# Altium schematic sheet 1_FOCUS.SchDoc(1_FOCUS)
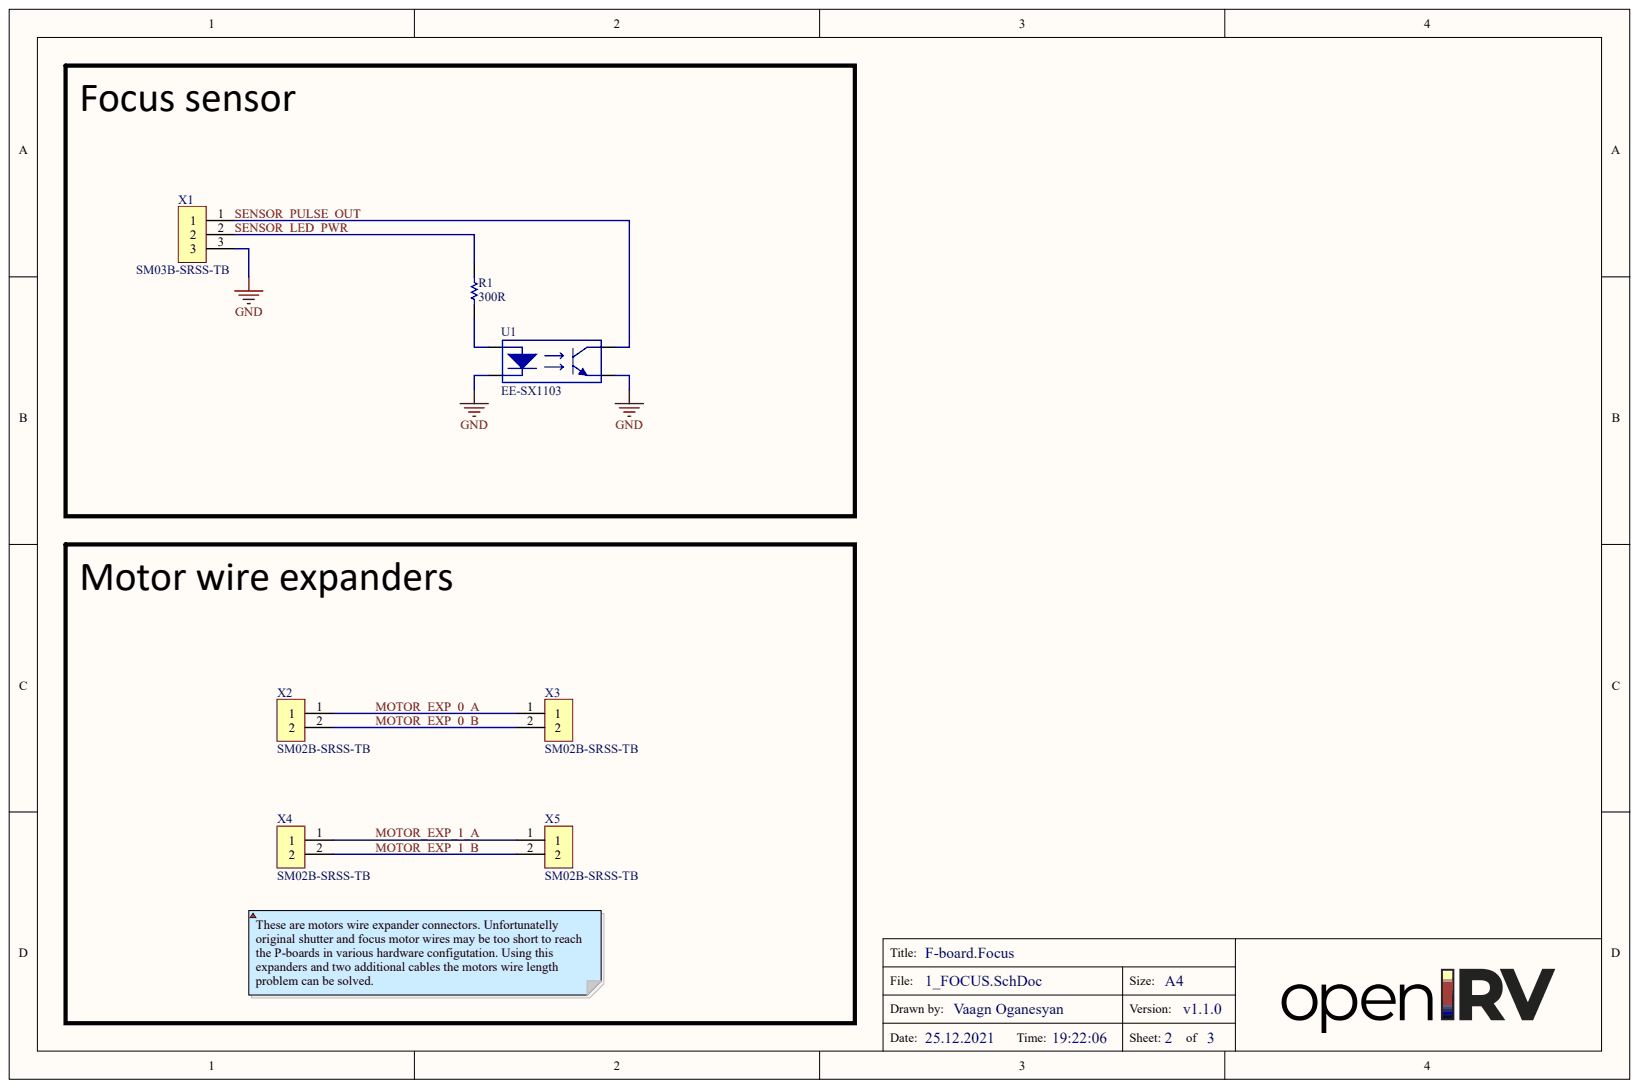
[diagram p0: 1_FOCUS.SchDoc]

## Parts
R1  300R  [R0603[1608]]
U1  EE-SX1103  [EE-SX1103]
X1  SM03B-SRSS-TB  [SM03B-SRSS-TB]
X2  SM02B-SRSS-TB  [SM02B-SRSS-TB]
X3  SM02B-SRSS-TB  [SM02B-SRSS-TB]
X4  SM02B-SRSS-TB  [SM02B-SRSS-TB]
X5  SM02B-SRSS-TB  [SM02B-SRSS-TB]

## Nets
GND: U1.E U1.K X1.3
MOTOR_EXP_0_A: X2.1 X3.1
MOTOR_EXP_0_B: X2.2 X3.2
MOTOR_EXP_1_A: X4.1 X5.1
MOTOR_EXP_1_B: X4.2 X5.2
SENSOR_LED_PWR: R1.2 X1.2
SENSOR_PULSE_OUT: U1.C X1.1
NetR1_1: R1.1 U1.A

## Library data of the parts
R1 = RES: Power: 0.1W; Tolerance: 5%; Component Kind: Standard; Library Name: general.IntLib; Mounting: SMD; Value: 300R
U1 = EE-SX1103: Photomicrosensor; Component Kind: Standard; Library Name: general.IntLib
X1 = SM03B-SRSS-TB: Component Kind: Standard; Library Name: general.IntLib
X2-X5 = SM02B-SRSS-TB: Component Kind: Standard; Library Name: general.IntLib
